# Altium schematic sheet switch.SchDoc(switch)
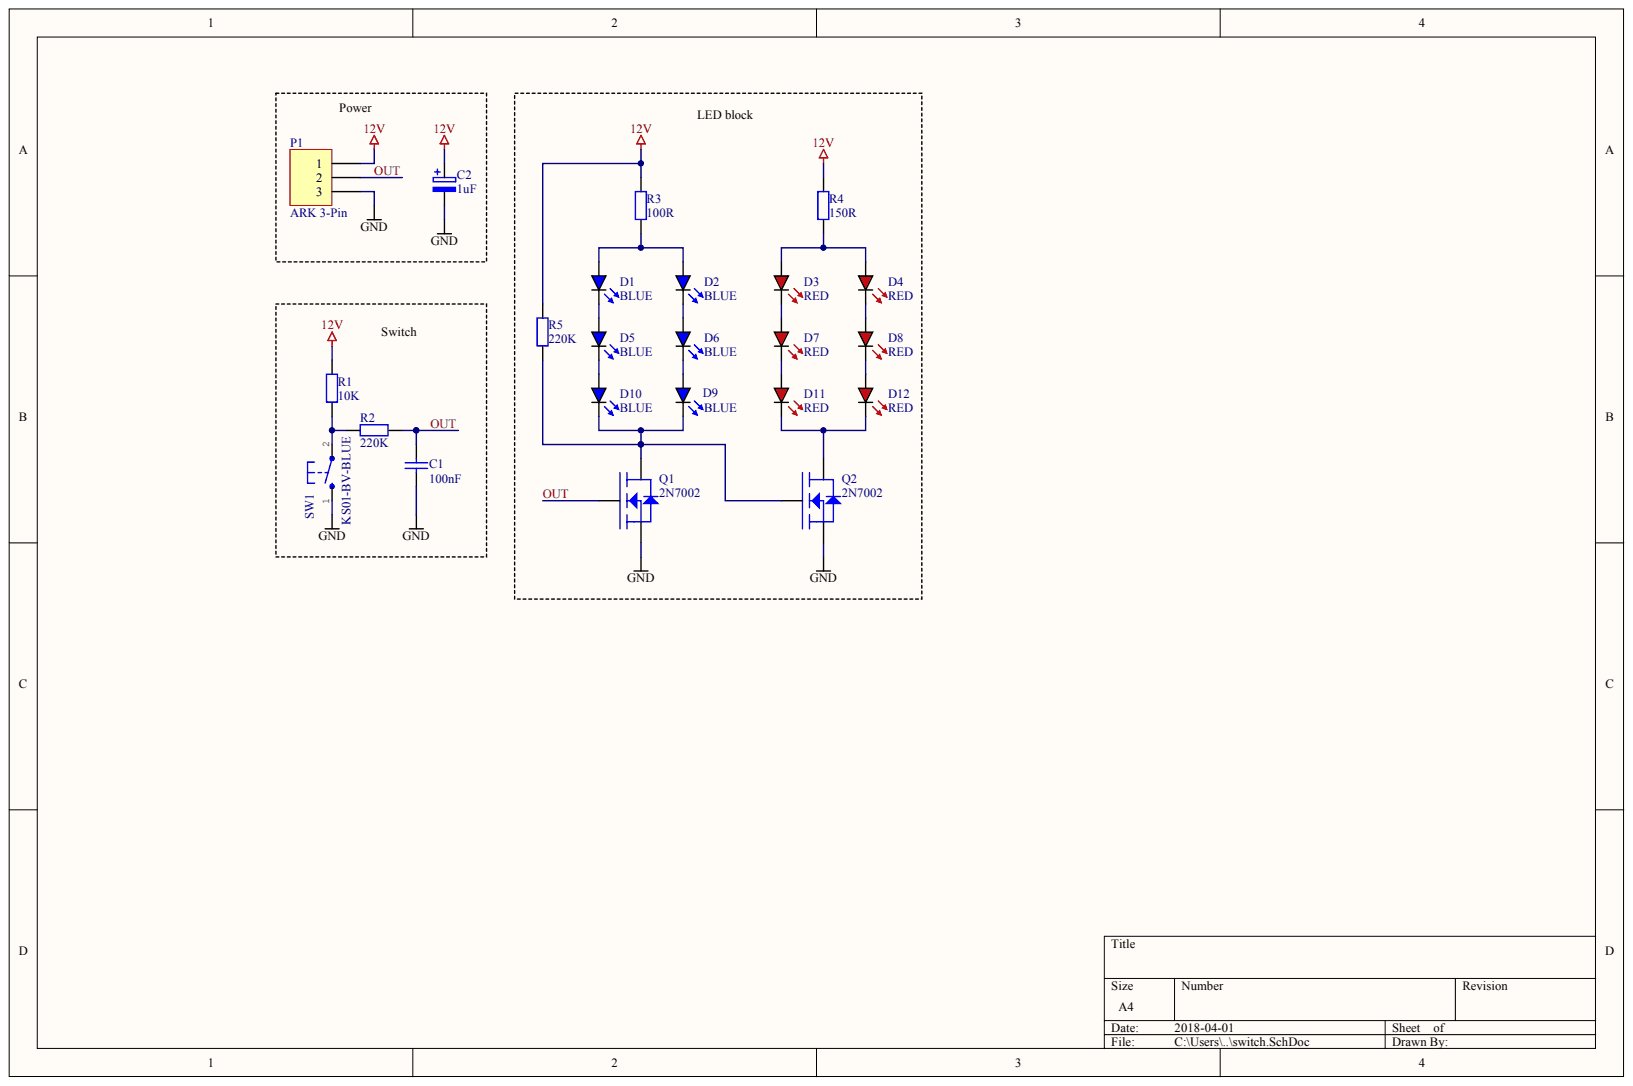
[diagram p0: switch.SchDoc]

## Parts
C1  100nF
C2  1uF
D1  BLUE
D2  BLUE
D3  RED
D4  RED
D5  BLUE
D6  BLUE
D7  RED
D8  RED
D9  BLUE
D10  BLUE
D11  RED
D12  RED
P1  ARK 3-Pin
Q1  2N7002
Q2  2N7002
R1  10K
R2  220K
R3  100R
R4  150R
R5  220K
SW1  KS01-BV-BLUE

## Nets
12V: C2.1 P1.1 R1.2 R3.2 R4.2 R5.2
GND: C1.2 C2.2 P1.3 Q1.2 Q2.2 SW1.1
OUT: C1.1 P1.2 Q1.1 R2.2
NetD1_1: D1.1 D5.2
NetD1_2: D1.2 D2.2 R3.1
NetD2_1: D2.1 D6.2
NetD3_1: D3.1 D7.2
NetD3_2: D3.2 D4.2 R4.1
NetD4_1: D4.1 D8.2
NetD5_1: D5.1 D10.2
NetD6_1: D6.1 D9.2
NetD7_1: D7.1 D11.2
NetD8_1: D8.1 D12.2
NetD9_1: D9.1 D10.1 Q1.3 Q2.1 R5.1
NetD11_1: D11.1 D12.1 Q2.3
NetR1_1: R1.1 R2.1 SW1.2
